# Altium schematic sheet ADC and CAN.SchDoc
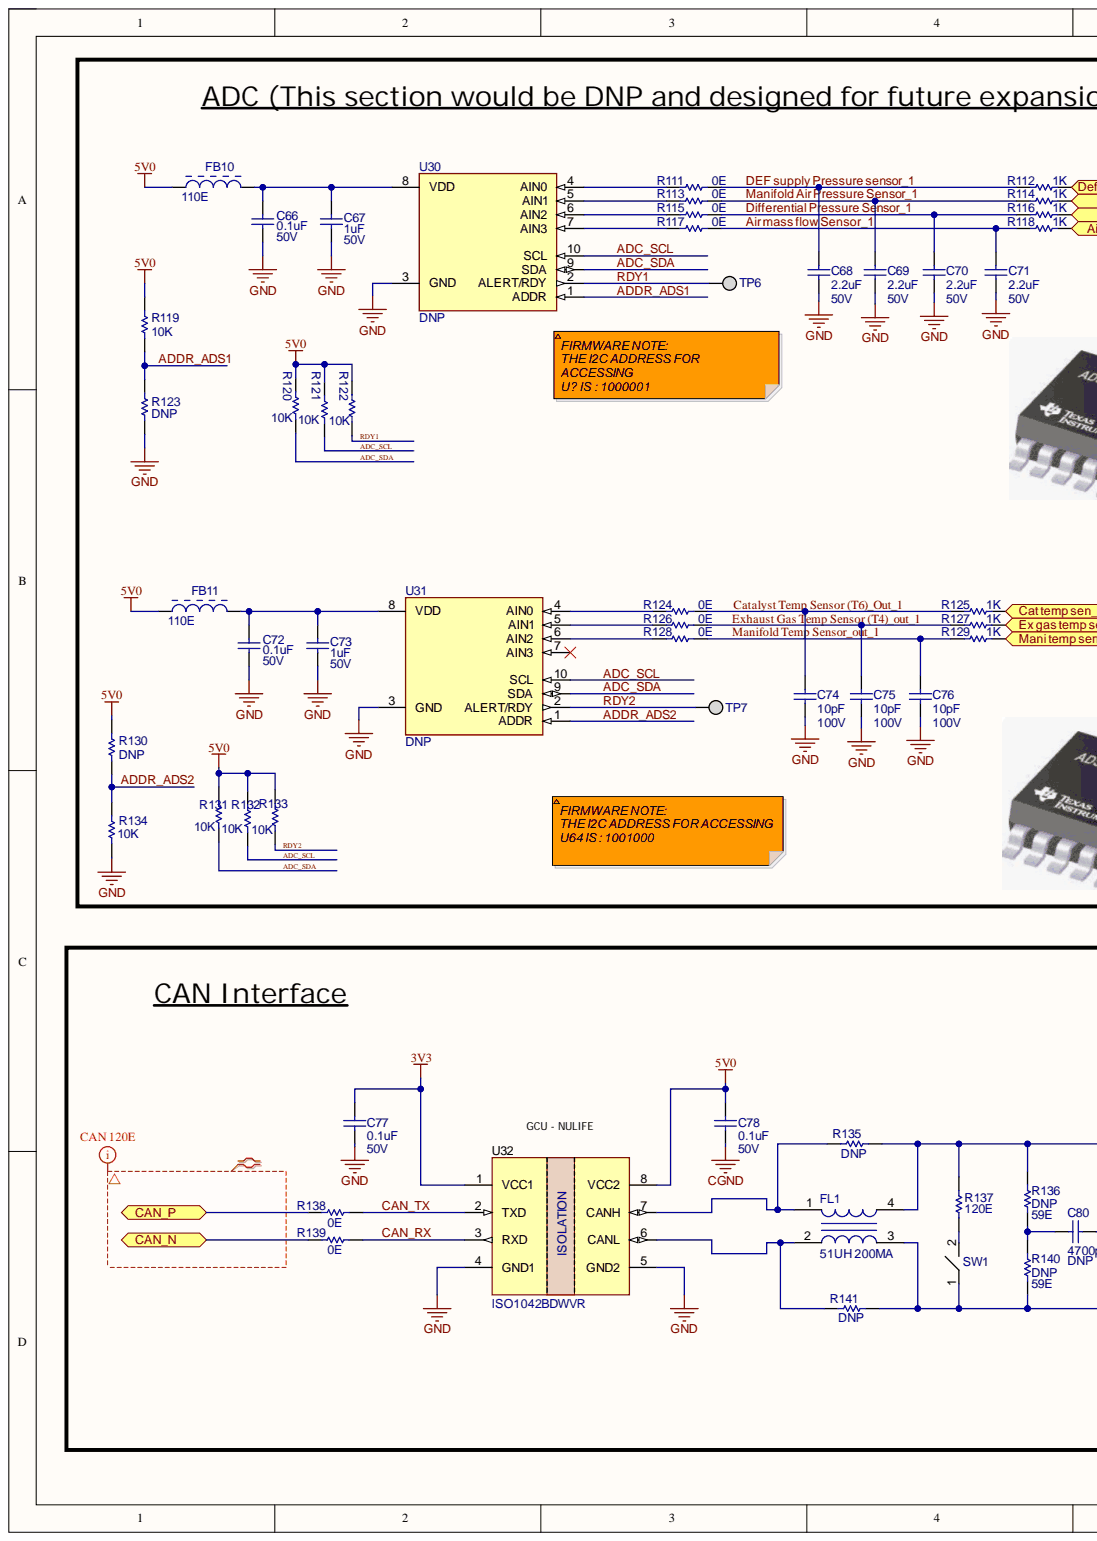
[diagram p0: ADC and CAN.SchDoc, left]
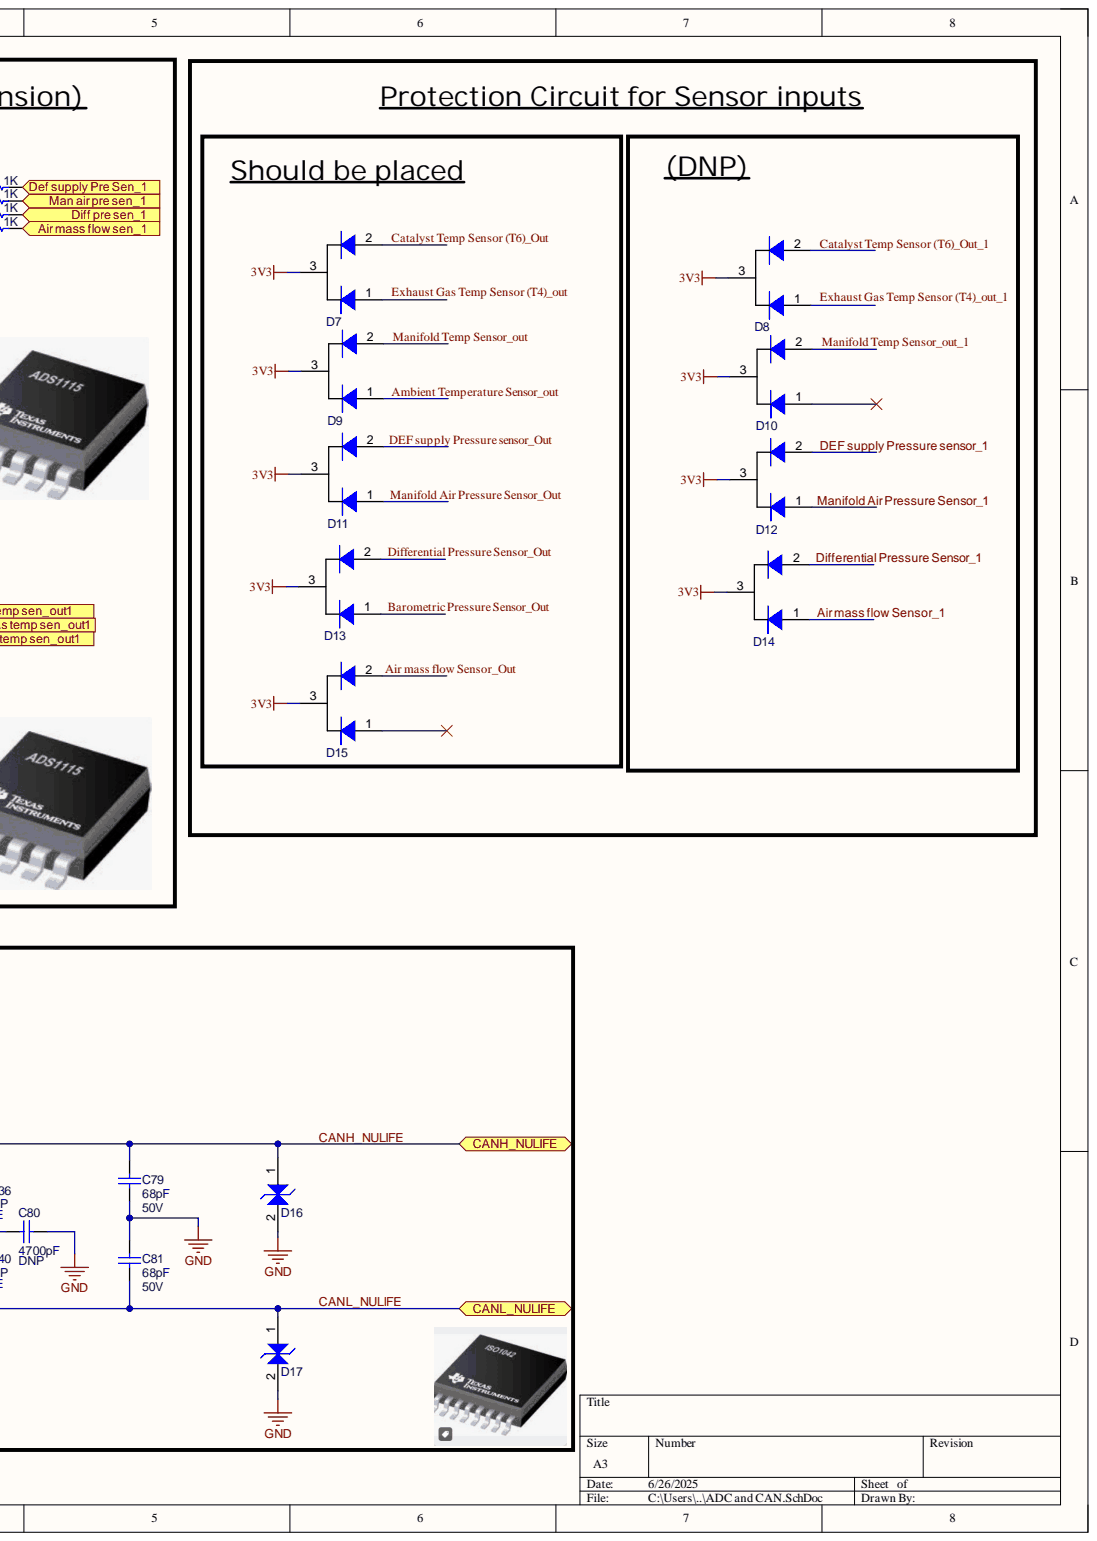
[diagram p1: ADC and CAN.SchDoc, right]

## Parts
C66  0.1uF  Voltage: 50V  [CAP-0805]
C67  1uF  Voltage Rating: 50V  [CAP-0805]
C68  2.2uF  Voltage: 50V  [CAP-0603]
C69  2.2uF  Voltage: 50V  [CAP-0603]
C70  2.2uF  Voltage: 50V  [CAP-0603]
C71  2.2uF  Voltage: 50V  [CAP-0603]
C72  0.1uF  Voltage: 50V  [CAP-0805]
C73  1uF  Voltage Rating: 50V  [CAP-0805]
C74  10pF  Voltage: 100V  [CAP-0603]
C75  10pF  Voltage: 100V  [CAP-0603]
C76  10pF  Voltage: 100V  [CAP-0603]
C77  0.1uF  Voltage: 50V  [CAP-0805]
C78  0.1uF  Voltage: 50V  [CAP-0805]
C79  68pF  Voltage: 50V  [CAP-0603]
C80  DNP  value 4700pF  [CAP-0603]
C81  68pF  Voltage: 50V  [CAP-0603]
D7  SDM40E20LC-7  [SOT-23-3]  (value not drawn)
D8  SDM40E20LC-7  [SOT-23-3]  (value not drawn)
D9  SDM40E20LC-7  [SOT-23-3]  (value not drawn)
D10  SDM40E20LC-7  [SOT-23-3]  (value not drawn)
D11  SDM40E20LC-7  [SOT-23-3]  (value not drawn)
D12  SDM40E20LC-7  [SOT-23-3]  (value not drawn)
D13  SDM40E20LC-7  [SOT-23-3]  (value not drawn)
D14  SDM40E20LC-7  [SOT-23-3]  (value not drawn)
D15  SDM40E20LC-7  [SOT-23-3]  (value not drawn)
D16  SMAJ5.0CA  [DO-214AC]  (value not drawn)
D17  SMAJ5.0CA  [DO-214AC]  (value not drawn)
FB10  110E  [FB-0805]
FB11  110E  [FB-0805]
FL1  51UH 200MA  [FIL_ACT45B-510-2P-TL003]
R111  0E  [RES-0603]
R112  1K  [RES-0603]
R113  0E  [RES-0603]
R114  1K  [RES-0603]
R115  0E  [RES-0603]
R116  1K  [RES-0603]
R117  0E  [RES-0603]
R118  1K  [RES-0603]
R119  10K  [RES-0603]
R120  10K  [RES-0603]
R121  10K  [RES-0603]
R122  10K  [RES-0603]
R123  DNP  [RES-0603]
R124  0E  [RES-0603]
R125  1K  [RES-0603]
R126  0E  [RES-0603]
R127  1K  [RES-0603]
R128  0E  [RES-0603]
R129  1K  [RES-0603]
R130  DNP  [RES-0603]
R131  10K  [RES-0603]
R132  10K  [RES-0603]
R133  10K  [RES-0603]
R134  10K  [RES-0603]
R135  DNP  [RES-0603]
R136  DNP  value 59E  [RES-0603]
R137  120E  [RES-0603]
R138  0E  [RES-0603]
R139  0E  [RES-0603]
R140  DNP  value 59E  [RES-0603]
R141  DNP  [RES-0603]
SW1  DS04-254-2-01BK-SMT  [SW_DS04-254-2-01BK-SMT-TR]  (value not drawn)
TP6  Test Point  [TestPoint]  (value not drawn)
TP7  Test Point  [TestPoint]  (value not drawn)
U30  DNP  [10-VSSOP]
U31  DNP  [10-VSSOP]
U32  ISO1042BDWVR  [D0008A_N]

## Ports
Air mass flow sen_1, CAN_N, CAN_P, CANH_NULIFE, CANL_NULIFE, Cat temp sen_out1, Def supply Pre Sen_1, Diff pre sen_1, Ex gas temp sen_out1, Man air pre sen_1, Mani temp sen_out1

## Nets
3V3: C77.2 D7.3 D8.3 D9.3 D10.3 D11.3 D12.3 D13.3 D14.3 D15.3 U32.1(VCC1)
5V0: C78.2 FB10.1 FB11.1 R119.2 R120.2 R121.2 R122.2 R130.2 R131.2 R132.2 R133.2 U32.8(VCC2)
CGND: C78.1
GND: C66.1 C67.1 C68.2 C69.2 C70.2 C71.2 C72.1 C73.1 C74.2 C75.2 C76.2 C77.1 C79.2 C80.1 C81.1 D16.2 D17.2 R123.1 R134.1 U30.3(GND) U31.3(GND) U32.4(GND1) U32.5(GND2)
ADC_SCL: R121.1 R132.1 U30.10(SCL) U31.10(SCL)
ADC_SDA: R120.1 R131.1 U30.9(SDA) U31.9(SDA)
ADDR_ADS1: R119.1 R123.2 U30.1(ADDR)
ADDR_ADS2: R130.1 R134.2 U31.1(ADDR)
Air mass flow sen_1: R118.1 ; port Air mass flow sen_1
Air mass flow Sensor_1: C71.1 D14.1 R117.2 R118.2
Air mass flow Sensor_Out: D15.2
Ambient Temperature Sensor_out: D9.1
Barometric Pressure Sensor_Out: D13.1
CAN_N: R139.2 ; port CAN_N
CAN_P: R138.2 ; port CAN_P
CAN_RX: R139.1 U32.3(RXD)
CAN_TX: R138.1 U32.2(TXD)
CANH_NULIFE: C79.1 D16.1 FL1.4 R135.1 R136.2 R137.2 ; port CANH_NULIFE
CANL_NULIFE: C81.2 D17.1 FL1.3 R140.1 R141.1 SW1.1 ; port CANL_NULIFE
Cat temp sen_out1: R125.1 ; port Cat temp sen_out1
Catalyst Temp Sensor (T6)_Out: D7.2
Catalyst Temp Sensor (T6)_Out_1: C74.1 D8.2 R124.2 R125.2
Def supply Pre Sen_1: R112.1 ; port Def supply Pre Sen_1
DEF supply Pressure sensor_1: C68.1 D12.2 R111.2 R112.2
DEF supply Pressure sensor_Out: D11.2
Diff pre sen_1: R116.1 ; port Diff pre sen_1
Differential Pressure Sensor_1: C70.1 D14.2 R115.2 R116.2
Differential Pressure Sensor_Out: D13.2
Ex gas temp sen_out1: R127.1 ; port Ex gas temp sen_out1
Exhaust Gas Temp Sensor (T4)_out_1: C75.1 R126.2 R127.2
Man air pre sen_1: R114.1 ; port Man air pre sen_1
Mani temp sen_out1: R129.1 ; port Mani temp sen_out1
Manifold Air Pressure Sensor_1: C69.1 D12.1 R113.2 R114.2
Manifold Air Pressure Sensor_Out: D11.1
Manifold Temp Sensor_out: D9.2
Manifold Temp Sensor_out_1: C76.1 D10.2 R128.2 R129.2
RDY1: R122.1 TP6.1 U30.2(ALERT/RDY)
RDY2: R133.1 TP7.1 U31.2(ALERT/RDY)
NetC66_2: C66.2 C67.2 FB10.2 U30.8(VDD)
NetC72_2: C72.2 C73.2 FB11.2 U31.8(VDD)
NetC80_2: C80.2 R136.1 R140.2
NetFL1_1: FL1.1 R135.2 U32.7(CANH)
NetFL1_2: FL1.2 R141.2 U32.6(CANL)
NetR111_1: R111.1 U30.4(AIN0)
NetR113_1: R113.1 U30.5(AIN1)
NetR115_1: R115.1 U30.6(AIN2)
NetR117_1: R117.1 U30.7(AIN3)
NetR124_1: R124.1 U31.4(AIN0)
NetR126_1: R126.1 U31.5(AIN1)
NetR128_1: R128.1 U31.6(AIN2)
NetR137_1: R137.1 SW1.2
Exhaust Gas Temp Sensor (T4)_out:

Not connected: D7 1; D8 1; D10 1; D15 1; U31 7(AIN3)

## Library data of the parts
C66 C72 = GRM155R71C104KA88D: CAP CER 0.1UF 50V X7R 0805; Manufacturer: KYOCERA AVX; Part Number: 08055C104J4Z2A; Component Kind: Standard; ComponentLink1Description: Datasheet link; ComponentLink1URL: http://search.murata.co.jp/Ceramy/PnsearchViewAction.do?HnOFG=ON&HnNFG=ON&SEL_CNT=50&sLang=en&sKey=GRM155R71C104KA88D&x=9&y=9&search=startwith; Library Name: Capacitor_Ceramic.IntLib; Value: 0.1uF
C67 C73 = CAP_0805_N: CAP CER 1UF 50V X7R 0805; Manufacturer: Samsung Electro-Mechanics; Part Number: CL21B105KBFNNNG; Tolerance: ±1%; Component Kind: Standard; Library Name: MB_Sch_Lib.SchLib; Operating Temperature: -55C ~ 125C; Package / Case: 0805 (2012 metric); Temperature Coefficient: X7R; Value: 1uF
C68-C71 = CAP: CAP CER 2.2UF 10V X7R 0603; Manufacturer: Samsung Electro-Mechanics; Part Number: CL10B225KP8NNNC; Tolerance: ±1%; Component Kind: Standard; Library Name: Daughter_board_SE3_V1.SCHLIB; Package: 0603; Technology: SMT; Value: 2.2uF
C74-C76 = CAP: CAP CER 10PF 100V C0G/NP0 0603; Manufacturer: Murata Electronics; Part Number: GCM1885C2A100JA16D; Tolerance: ±1%; Component Kind: Standard; Library Name: Daughter_board_SE3_V1.SCHLIB; Package: 0603; Technology: SMT; Value: 10pF
C77 C78 = CAP: CAP CER 0.1UF 50V X7R 0805; Manufacturer: KYOCERA AVX; Part Number: 08055C104J4Z2A; Component Kind: Standard; Library Name: CAN_20-06-2023.SCHLIB; Package: 0603; Value: 0.1uF
C79 C81 = CAP: CAP CER 68PF 50V C0G/NP0 0603; Manufacturer: KEMET; Part Number: C0603C680J5GACAUTO; Component Kind: Standard; Library Name: CAN_20-06-2023.SCHLIB; Package: 0603; Value: 68pF
C80 = CAP: CAP CER 4700PF 50V C0G/NP0 0603; Manufacturer: Murata Electronics; Part Number: GRM1885C1H472JA01D; Component Kind: Standard; Library Name: CAN_20-06-2023.SCHLIB; Package: 0603; Voltage: 50V
D7-D15 = (no symbol name): DIODE ARR SCHOTT 20V 400MA SOT23; Manufacturer: Diodes Incorporated; Component Kind: Standard; Part Number: SDM40E20LC-7
D16 D17 = SMCJ12CA: TVS DIODE 5VWM 9.2VC DO214AC; Manufacturer: Littelfuse Inc.; Value: 5V; Component Kind: Standard; Library Name: SamacSys.SchLib; Package: DO-214AC; Part Number: SMAJ5.0CA
FB10 FB11 = IND-FB-2: FERRITE BEAD 110 OHM 0805 1LN; Manufacturer: Murata Electronics; Part Number: BLM21SP111SZ1D; Current: 5A; Value: 110 Ohm; Component Kind: Standard; Library Name: Daughter_board_SE3_V1.SCHLIB; Package Reference: 0805
FL1 = ACT45B-510-2P-TL003: CMC 51UH 200MA 2LN 2KOHM SMD; Manufacturer: Eaton - Electronics Division; Part Number: ACE1V4532-510-R; Component Kind: Standard; Package: 0.177" L x 0.126" W (4.50mm x 3.20mm); Value: 51uH
R111 R113 R115 R117 R124 R126 R128 = RES-2: RES SMD 0 OHM JUMPER 1/10W 0603; Manufacturer: YAGEO; Part Number: AC0603FR-070RL; Power: 0.1W; Tolerance: ±1%; Component Kind: Standard; Library Name: Daughter_board_SE3_V1.SCHLIB; Package: 0603; Value: 0E
R112 R114 R116 R118 R125 R127 R129 = RES-2: RES SMD 1K OHM 1% 1/10W 0603; Manufacturer: YAGEO; Part Number: AC0603FR-071KL; Power Rating: 100mW; Component Kind: Standard; Library Name: Daughter_board_SE3_V1.SCHLIB; Package: 0603; Tolerance: ±1%; Value: 1K
R119 R123 R130 R134 = RES-2: RES SMD 10K OHM 1% 1/10W 0603; Manufacturer: YAGEO; Part Number: AC0603FR-0710KL; Power Rating: 100mW; Component Kind: Standard; Library Name: Daughter_board_SE3_V1.SCHLIB; Package: 0603; Tolerance: ±1%; Value: 10K
R120-R122 R131-R133 = RES-2_14: RES SMD 10K OHM 1% 1/10W 0603; Manufacturer: YAGEO; Part Number: AC0603FR-0710KL; Power Rating: 100mW; Component Kind: Standard; Package: 0603; Tolerance: ±1%; Value: 10K; Library Name: GENSET CONTROLLER BETA V01.SCHLIB
R135 R141 = RES-2: RES 0 OHM JUMPER 1/10W 0603; Manufacturer: YAGEO; Part Number: AC0603JR-130RL; Power: 0.1W; Tolerance: ±1%; Value: 0E; Component Kind: Standard; Library Name: Daughter_board_SE3_V1.SCHLIB; Package: 0603
R136 R140 = Resistor: RES SMD 59 OHM 1% 1/10W 0603; Manufacturer: YAGEO; Part Number: AC0603FR-0759RL; Component Kind: Standard; Library Name: Miscellaneous Devices.IntLib; Tolerance: ±1%
  R140: ComponentLink1Description: Datasheet link; ComponentLink1URL: http://www.yageo.com/pdf/Pu-RC0402_51_PbFree_L_2.pdf
R137 = Resistor: RES SMD 120 OHM 1% 1/10W 0603; Manufacturer: YAGEO; Part Number: AC0603FR-07120RL; Component Kind: Standard; Library Name: Miscellaneous Devices.IntLib; Package / Case: 0603 (1608 Metric); Tolerance: ±1%; Value: 120E
R138 R139 = RES-2: RES 0 OHM JUMPER 1/10W 0603; Manufacturer: YAGEO; Part Number: AC0603JR-130RL; Power: 0.1W; Tolerance: ±1%; Component Kind: Standard; Library Name: Daughter_board_SE3_V1.SCHLIB; Package: 0603; Value: 0E
SW1 = DS04-254-2-01BK-SMT: SWITCH SLIDE DIP SPST 0.025A 24V; Manufacturer: Same Sky (Formerly CUI Devices); Component Kind: Standard; Part Number: DS04-254-2-01BK-SMT
U30 U31 = ADS1115IDGSR: IC ADC 16BIT SIGMA-DELTA 10VSSOP, ADS1115IDGSR; Manufacturer: Texas Instruments; Component Kind: Standard; Package: 10-VSSOP; Part Number: ADS1115IDGSR
U32 = TCAN332: IC TRANSCEIVER FULL 1/1 8SOIC; Manufacturer: Texas Instruments; Component Kind: Standard; Library Name: Miscellaneous Devices.IntLib; Part Number: ISO1042BDWVR
TP6 TP7 = (no symbol name): Component Kind: Standard; Description: Test Point
